# Altium schematic sheet [03] - POWER HIGH STAGE.SchDoc("[03] - POWER HIGH STAGE")
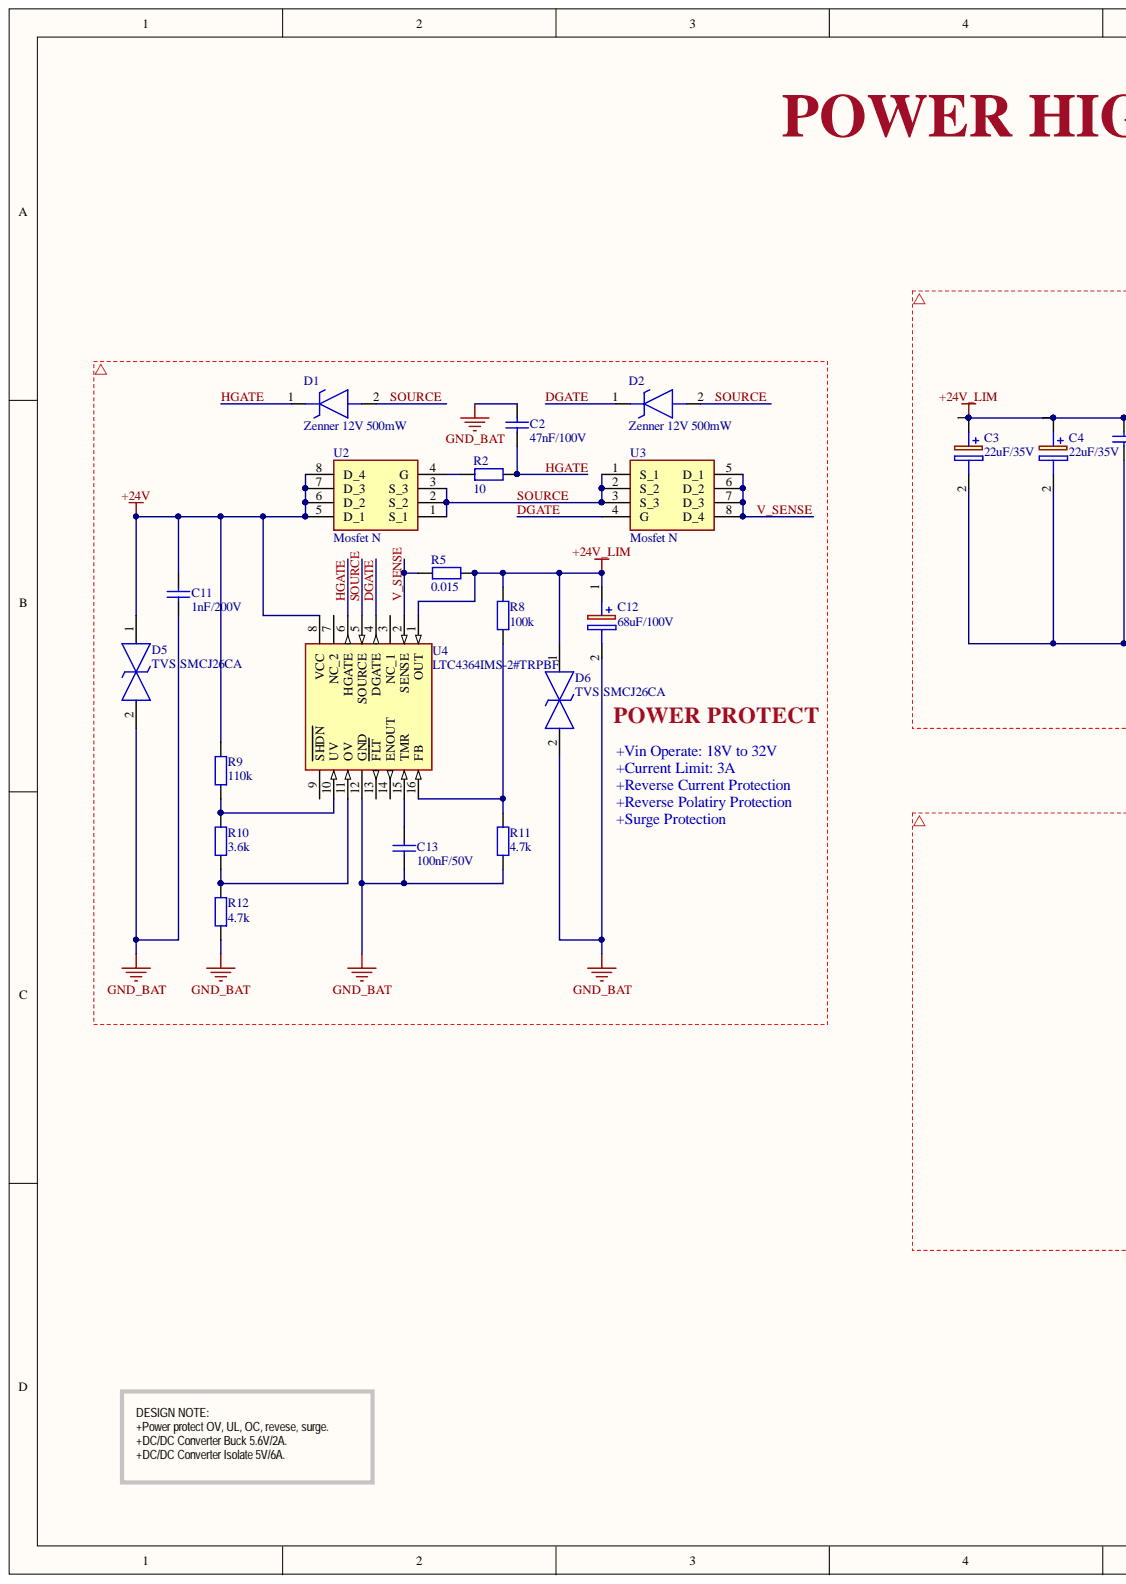
[diagram p0: [03] - POWER HIGH STAGE.SchDoc, left]
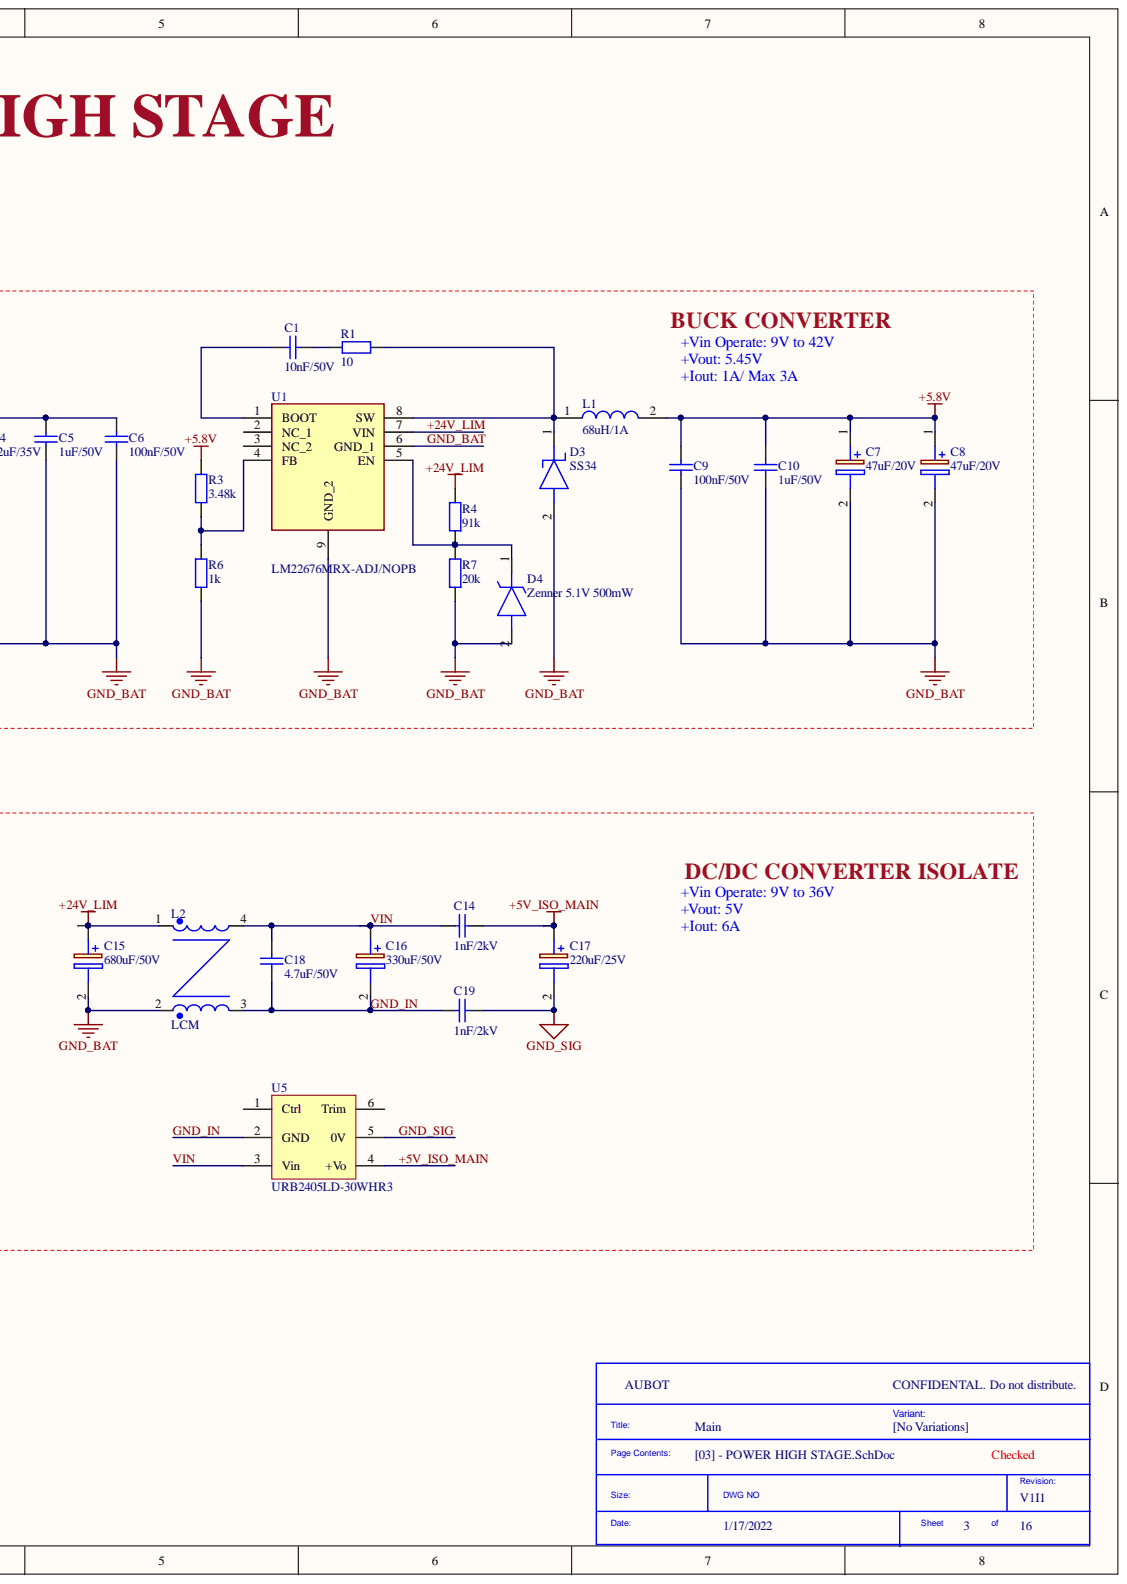
[diagram p1: [03] - POWER HIGH STAGE.SchDoc, right]

## Parts
C1  10nF/50V  [CAP 0805]
C2  47nF/100V  [CAP 0805]
C3  22uF/35V  [TANTALUM 2917]
C4  22uF/35V  [TANTALUM 2917]
C5  1uF/50V  [CAP 0805]
C6  100nF/50V  [CAP 0805]
C7  47uF/20V  [TANTALUM 2917]
C8  47uF/20V  [TANTALUM 2917]
C9  100nF/50V  [CAP 0805]
C10  1uF/50V  [CAP 0805]
C11  1nF/200V  [CAP 0805]
C12  68uF/100V  [CAP 10x16]
C13  100nF/50V  [CAP 0805]
C14  1nF/2kV  [CAP  DISC 5]
C15  680uF/50V  [CAP 16x20]
C16  330uF/50V  [CAP 12.5x20]
C17  220uF/25V  [CAP 8x11.5]
C18  4.7uF/50V  [CAP 1206]
C19  1nF/2kV  [CAP  DISC 5]
D1  Zenner 12V 500mW  [ZENNER SMD LL-34]
D2  Zenner 12V 500mW  [ZENNER SMD LL-34]
D3  SS34  [SS34]
D4  Zenner 5.1V 500mW  [ZENNER SMD LL-34]
D5  TVS SMCJ26CA  Manufacturer Part Number: SMCJ26CA  [TVS SMCJ]
D6  TVS SMCJ26CA  Manufacturer Part Number: SMCJ26CA  [TVS SMCJ]
L1  68uH/1A  [INDUCTOR 6x6x4.5]
L2  LCM  [LCM FLD2D]
R1  10  [RES 0805]
R2  10  [RES 0805]
R3  3.48k  [RES 0805]
R4  91k  [RES 0805]
R5  0.015  [RES 1206]
R6  1k  [RES 0805]
R7  20k  [RES 0805]
R8  100k  [RES 0805]
R9  110k  [RES 0805]
R10  3.6k  [RES 0805]
R11  4.7k  [RES 0805]
R12  4.7k  [RES 0805]
U1  LM22676MRX-ADJ/NOPB  [SOIC8-PowerPad]
U2  Mosfet N  [DMTH8003SPS-13]
U3  Mosfet N  [DMTH8003SPS-13]
U4  LTC4364IMS-2#TRPBF  [LTC4364IMS-2#TRPBF]
U5  URB2405LD-30WHR3  [URB2405LD-30WR3]

## Nets
+5.8V: C7.1 C8.1 C9.1 C10.2 L1.2 R3.1
+24V: C11.1 D5.1 R9.2 U2.5(D_1) U2.6(D_2) U2.7(D_3) U2.8(D_4) U4.8(VCC)
+5V_ISO_MAIN: C14.1 C17.1 U5.4(+Vo)
+24V_LIM: C3.1 C4.1 C5.2 C6.2 C12.1 C15.1 D6.1 L2.1 R4.2 R5.2 R8.2 U1.7(VIN) U4.1(OUT)
DGATE: D2.1 U3.4(G) U4.4(DGATE)
GND_BAT: C2.1 C3.2 C4.2 C5.1 C6.1 C7.2 C8.2 C9.2 C10.1 C11.2 C12.2 C13.2 C15.2 D3.2 D4.2 D5.2 D6.2 L2.2 R6.2 R7.1 R11.1 R12.1 U1.6(GND_1) U1.9(GND_2) U4.12(GND)
GND_IN: C16.2 C18.1 C19.2 L2.3 U5.2(GND)
GND_SIG: C17.2 C19.1 U5.5(0V)
HGATE: C2.2 D1.1 R2.2 U4.6(HGATE)
SOURCE: D1.2 D2.2 U2.1(S_1) U2.2(S_2) U2.3(S_3) U3.1(S_1) U3.2(S_2) U3.3(S_3) U4.5(SOURCE)
V_SENSE: R5.1 U3.5(D_1) U3.6(D_2) U3.7(D_3) U3.8(D_4) U4.2(SENSE)
VIN: C14.2 C16.1 C18.2 L2.4 U5.3(Vin)
NetC1_1: C1.1 R1.1
NetC1_2: C1.2 U1.1(BOOT)
NetC13_1: C13.1 U4.15(TMR)
NetD3_1: D3.1 L1.1 R1.2 U1.8(SW)
NetD4_1: D4.1 R4.1 R7.2 U1.5(EN)
NetR2_1: R2.1 U2.4(G)
NetR3_2: R3.2 R6.1 U1.4(FB)
NetR8_1: R8.1 R11.2 U4.16(FB)
NetR9_1: R9.1 R10.2 U4.10(UV)
NetR10_1: R10.1 R12.2 U4.11(OV)

Not connected: U1 2(NC_1) 3(NC_2); U4 3(NC_1) 7(NC_2) 9(SHDN) 13(FLT) 14(ENOUT); U5 1(Ctrl) 6(Trim)
